# Altium schematic sheet Sheet2.SchDoc
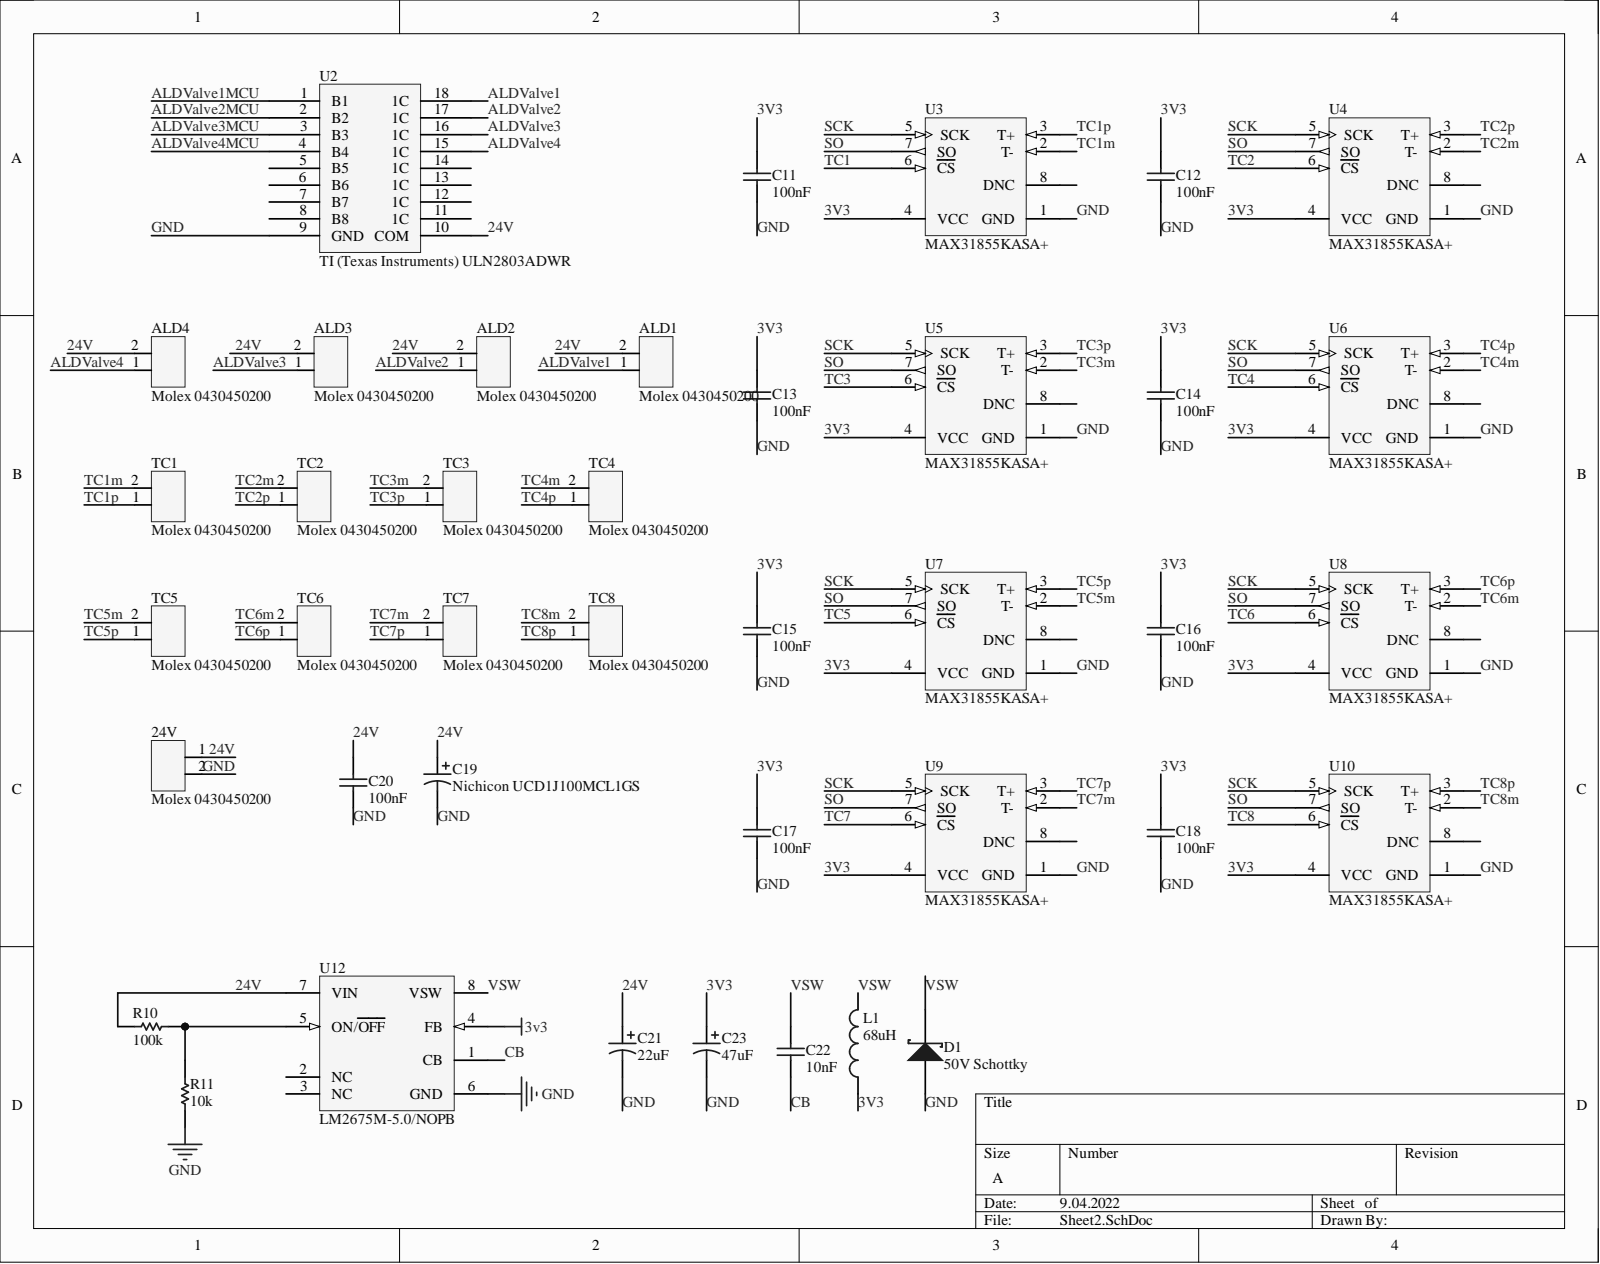
[diagram p0: Sheet2.SchDoc]

## Parts
24V  Molex 0430450200  Manufacturer Part Number: 0430450200  [PCB-lpc9z9t8uewl5xeystzy-1]
ALD1  Molex 0430450200  [PCB-lpc9z9t8uewl5xeystzy-1]
ALD2  Molex 0430450200  Manufacturer Part Number: 0430450200  [PCB-lpc9z9t8uewl5xeystzy-1]
ALD3  Molex 0430450200  Manufacturer Part Number: 0430450200  [PCB-lpc9z9t8uewl5xeystzy-1]
ALD4  Molex 0430450200  Manufacturer Part Number: 0430450200  [PCB-lpc9z9t8uewl5xeystzy-1]
C11  100nF  [CAPC1005X56X25NL10T15]
C12  100nF  [CAPC1005X56X25NL10T15]
C13  100nF  [CAPC1005X56X25NL10T15]
C14  100nF  [CAPC1005X56X25NL10T15]
C15  100nF  [CAPC1005X56X25NL10T15]
C16  100nF  [CAPC1005X56X25NL10T15]
C17  100nF  [CAPC1005X56X25NL10T15]
C18  100nF  [CAPC1005X56X25NL10T15]
C19  Nichicon UCD1J100MCL1GS  [PCB-1g0l0k2l0mdn54ua3y2n-1]
C20  100nF  [CAPC1005X56X25NL10T15]
C21  22uF  [PCB-1g0l0k2l0mdn54ua3y2n-1]
C22  10nF  [CAPC1005X56X25NL10T15]
C23  47uF  [PCB-1g0l0k2l0mdn54ua3y2n-1]
D1  50V Schottky  [SMB]
L1  68uH  [PCB-55q20qg1bv3zb075xii5-1]
R10  100k  [PCB-xna89c7rj2sv02b80bkg-1]
R11  10k  [PCB-xna89c7rj2sv02b80bkg-1]
TC1  Molex 0430450200  [PCB-lpc9z9t8uewl5xeystzy-1]
TC2  Molex 0430450200  Manufacturer Part Number: 0430450200  [PCB-lpc9z9t8uewl5xeystzy-1]
TC3  Molex 0430450200  Manufacturer Part Number: 0430450200  [PCB-lpc9z9t8uewl5xeystzy-1]
TC4  Molex 0430450200  Manufacturer Part Number: 0430450200  [PCB-lpc9z9t8uewl5xeystzy-1]
TC5  Molex 0430450200  [PCB-lpc9z9t8uewl5xeystzy-1]
TC6  Molex 0430450200  Manufacturer Part Number: 0430450200  [PCB-lpc9z9t8uewl5xeystzy-1]
TC7  Molex 0430450200  Manufacturer Part Number: 0430450200  [PCB-lpc9z9t8uewl5xeystzy-1]
TC8  Molex 0430450200  Manufacturer Part Number: 0430450200  [PCB-lpc9z9t8uewl5xeystzy-1]
U2  TI (Texas Instruments) ULN2803ADWR  [PCB-19353911-1]
U3  MAX31855KASA+  [MAXM-S8+4_N]
U4  MAX31855KASA+  [MAXM-S8+4_N]
U5  MAX31855KASA+  [MAXM-S8+4_N]
U6  MAX31855KASA+  [MAXM-S8+4_N]
U7  MAX31855KASA+  [MAXM-S8+4_N]
U8  MAX31855KASA+  [MAXM-S8+4_N]
U9  MAX31855KASA+  [MAXM-S8+4_N]
U10  MAX31855KASA+  [MAXM-S8+4_N]
U12  LM2675M-5.0/NOPB  [M08A_M]

## Nets
3V3: C11.2 C12.2 C13.2 C14.2 C15.2 C16.2 C17.2 C18.2 C23.1 L1.1 U3.4(VCC) U4.4(VCC) U5.4(VCC) U6.4(VCC) U7.4(VCC) U8.4(VCC) U9.4(VCC) U10.4(VCC) U12.4(FB)
24V: 24V.1 ALD1.2 ALD2.2 ALD3.2 ALD4.2 C19.1 C20.2 C21.1 R10.2 U2.10(COM) U12.7(VIN)
ALDValve1: ALD1.1 U2.18(1C)
ALDValve1MCU: U2.1(B1)
ALDValve2: ALD2.1 U2.17(1C)
ALDValve2MCU: U2.2(B2)
ALDValve3: ALD3.1 U2.16(1C)
ALDValve3MCU: U2.3(B3)
ALDValve4: ALD4.1 U2.15(1C)
ALDValve4MCU: U2.4(B4)
CB: C22.1 U12.1(CB)
GND: 24V.2 C11.1 C12.1 C13.1 C14.1 C15.1 C16.1 C17.1 C18.1 C19.2 C20.1 C21.2 C23.2 D1.2 R11.2 U2.9(GND) U3.1(GND) U4.1(GND) U5.1(GND) U6.1(GND) U7.1(GND) U8.1(GND) U9.1(GND) U10.1(GND) U12.6(GND)
SCK: U3.5(SCK) U4.5(SCK) U5.5(SCK) U6.5(SCK) U7.5(SCK) U8.5(SCK) U9.5(SCK) U10.5(SCK)
SO: U3.7(SO) U4.7(SO) U5.7(SO) U6.7(SO) U7.7(SO) U8.7(SO) U9.7(SO) U10.7(SO)
TC1: U3.6(CS)
TC1m: TC1.2 U3.2(T-)
TC1p: TC1.1 U3.3(T+)
TC2: U4.6(CS)
TC2m: TC2.2 U4.2(T-)
TC2p: TC2.1 U4.3(T+)
TC3: U5.6(CS)
TC3m: TC3.2 U5.2(T-)
TC3p: TC3.1 U5.3(T+)
TC4: U6.6(CS)
TC4m: TC4.2 U6.2(T-)
TC4p: TC4.1 U6.3(T+)
TC5: U7.6(CS)
TC5m: TC5.2 U7.2(T-)
TC5p: TC5.1 U7.3(T+)
TC6: U8.6(CS)
TC6m: TC6.2 U8.2(T-)
TC6p: TC6.1 U8.3(T+)
TC7: U9.6(CS)
TC7m: TC7.2 U9.2(T-)
TC7p: TC7.1 U9.3(T+)
TC8: U10.6(CS)
TC8m: TC8.2 U10.2(T-)
TC8p: TC8.1 U10.3(T+)
VSW: C22.2 D1.1 L1.2 U12.8(VSW)
NetR10_1: R10.1 R11.1 U12.5(ON/OFF)

Not connected: U2 5(B5) 6(B6) 7(B7) 8(B8) 11(1C) 12(1C) 13(1C) 14(1C); U3 8(DNC); U4 8(DNC); U5 8(DNC); U6 8(DNC); U7 8(DNC); U8 8(DNC); U9 8(DNC); U10 8(DNC); U12 2(NC) 3(NC)

## Library data of the parts
24V ALD1-ALD4 TC1-TC8 = CMP-b9e170746c1a6626-2: Conn Wire to Board HDR 2 POS Solder RA Thru-Hole Micro-Fit 3.0Ã¢âÂ¢ Tray; Component Kind: Standard; Contact Plating: Tin; Flammability Rating: UL 94 V-0; Library Name: CommunityVault; Lifecycle Status: Not Listed by Manufacturer; Octopart URL: http://octopart.com/0430450200-molex-10481821; OctopartID: b9e170746c1a6626; Orientation: Right Angle; RoHS: Compliant
  ALD1 TC1 TC5: Manufacturer Part Number: 0430450200
C11-C18 C20 C22 = CMP-10875562-2: Capacitor 0.1 uF 16 Volt Y5 Volt -20% To 80% Surface Mount 0402 Paper Tape and Reel; Capacitance: 1e-07 F; Capacitance Tolerance: +80/-20%; Dielectric Characteristic: Y5V; Dielectric Material: Ceramic Multilayer; Lead-Free Status: Lead Free; Voltage Rating (DC): 16.0 V; Insulation Resistance: 1e+11 ?; Case/Package: 0402; Component Kind: Standard; Library Name: CommunityVault; Mounting Style: Surface Mount; Number of Pins: 2; Octopart Url: https://octopart.com/0402yg104zat2a-avx+interconnect+%2F+elco-38554; OctopartID: 7a36b33cc9caa5f1; Packaging: Cut Tape (CT); REACH SVHC Compliance: No SVHC; RoHS: Compliant; Size-Height: 0.00056 m; Size-Length: 0.001 m; Size-Thickness: 0.0008636 m; Size-Width: 0.0005 m
C19 = CMP-5924905658d086e3-1: CD Series 10 uF 63 V 105°C Ø6.3 x 5.8mm SMT Aluminum Electrolytic Cap; Capacitance: 10u; Capacitance Tolerance: ±20%; Lead-Free Status: Lead Free; Voltage Rating (DC): 63V; Component Kind: Standard; Digikey: 493-6395-1-ND; Library Name: CommunityVault; Manufacturer Part Number: UCD1J100MCL1GS; Mounting Style: Surface Mount; Octopart URL: http://octopart.com/ucd1j100mcl1gs-nichicon-7873682; OctopartID: 5924905658d086e3; Packaging: Reel; RoHS: Compliant; Size-Diameter: 0.0063 m; Size-Height: 0.0058 m
C21 C23 = CMP-5924905658d086e3-1: CD Series 10 uF 63 V 105°C Ø6.3 x 5.8mm SMT Aluminum Electrolytic Cap; Manufacturer Part Number: UCD1J100MCL1GS; Capacitance: 10u; Capacitance Tolerance: ±20%; Lead-Free Status: Lead Free; Voltage Rating (DC): 63V; Component Kind: Standard; Digikey: 493-6395-1-ND; Library Name: CommunityVault; Mounting Style: Surface Mount; Octopart URL: http://octopart.com/ucd1j100mcl1gs-nichicon-7873682; OctopartID: 5924905658d086e3; Packaging: Reel; RoHS: Compliant; Size-Diameter: 0.0063 m; Size-Height: 0.0058 m
D1 = CMP-814764-4: DIODE SCHOTTKY 40V 1A SMA; Current Rating: 1.0 A; Lead-Free Status: Lead Free; Polarity: Standard; Voltage Rating (DC): 40.0 V; Case/Package: DO-214; Component Kind: Standard; Library Name: CommunityVault; Lifecycle Status: Not Listed by Manufacturer; Mounting Style: Surface Mount; Number of Pins: 2; Octopart Url: https://octopart.com/ss14-fairchild+semiconductor-55390225; OctopartID: ce2a046ddb5d11ed; REACH SVHC Compliance: No SVHC; RoHS: Compliant
L1 = CMP-57049c55be0788c3-2: RF Choke Wirewound 1mH 10% 252KHz 50Q-Factor Ferrite 290mA 2.9Ohm DCR RDL Bag; Manufacturer Part Number: RL622-102K-RC; Lead Length: 0.005 m; Lead-Free Status: Lead Free; Q-Factor: 50.0; Self Resonant Frequency: 1300000.0 Hz; Shielding: Yes; Inductance: 0.001 H; Component Kind: Standard; Library Name: FavoritesLibrary; Mounting Style: Through Hole; Number of Pins: 2; Octopart URL: http://octopart.com/rl622-102k-rc-bourns-39570533; OctopartID: 57049c55be0788c3; Orientation: Vertical; Packaging: Box; REACH SVHC Compliance: No SVHC; RoHS: Compliant; Size-Diameter: 0.0085 m; Size-Height: 0.011 m; Size-Width: 0.0085 m
R10 R11 = CMP-4716668-10: Res Thick Film 0603 10K Ohm 1% 0.1W(1/10W) ?100ppm/C SMD Automotive Paper T/R; Manufacturer Part Number: CRCW060310K0FKEA; Lead-Free Status: Lead Free; Temperature Coefficient: 100.0 ppm/°C; Voltage Rating (DC): 75.0 V; Case/Package: 0603; Component Kind: Standard; Library Name: CommunityVault; Mounting Style: Surface Mount; Octopart URL: http://octopart.com/crcw060310k0fkea-vishay-55388213; OctopartID: 4aec80ab47bf952b; Power Rating: 0.1 W; Resistance: 10k; Resistance Tolerance: ±1%; RoHS: Compliant
U2 = CMP-19353911-2: TEXAS INSTRUMENTS   ULN2803ADWR   DARLINGTON TRANSISTOR ARRAY; Current Rating: 0.5 A; Drivers Per Package: 8; Lead-Free Status: Lead Free; Voltage Rating (DC): 50.0 V; Case/Package: SOIC; Component Kind: Standard; Library Name: CommunityVault; Lifecycle Status: Active; Mounting Style: Surface Mount; Number of Pins: 18; Octopart Url: https://octopart.com/uln2803adwr-texas+instruments-351822; OctopartID: 9c1b5514e73343dc; REACH SVHC Compliance: No SVHC; RoHS: Compliant
U3-U10 = CMP-1468732-1: Cold Junction Compensated Thermocouple to Digital Converter, 14 Bits Temperature Resolution, -40 to 125 degC, 8-Pin SOIC (S8+4), Pb-Free; Accuracy: ±6°C(Max); Dynamic Catalog: Thermocouple Amplifier, Conditioner; Function: Thermocouple Cold Junction Compensator; Other Names: 90-31855+K00; Output Alarm: No; Output Type: 3-Wire/SPIT Serial; Product Training Modules: Lead (SnPb) Finish for COTSLong-Term Supply Program; Reference Design Library: MAX31855EVKIT#: 14-bit Thermocouple Interface SPI; Sensing Temperature: -200°C ~ 1350°C; Sensor Type: External; Topology: ADC; Voltage - Supply: 3 V ~ 3.6 V; Category: Integrated Circuits (ICs); Ciiva URL: https://ciiva.com/part/MAX31855KASA+-1468732; CiivaID: 1468732; Component Kind: Standard; Family: PMIC - Thermal Management; Library Name: CommunityVault; Mounting Type: Surface Mount; Operating Temperature: -40°C ~ 125°C; Output Fan: No; Package / Case: 8-SOIC (0.154", 3.90mm Width); Packaging: Tube; Standard Package: 100; Supplier Device Package: 8-SOIC N
U12 = CMP-0069-00699-3: SIMPLE SWITCHER® Power Converter High Efficiency 1A Step-Down Voltage Regulator, 8-pin Narrow SOIC, Pb-Free; Current Rating: 1.0 A; Frequency: 260000.0 Hz; Lead-Free Status: Lead Free; Number of Outputs: 1; Output Voltage: 5.0 V; Quiescent Current: 0.0025 A; Topology: Buck; Case/Package: SOIC; Component Kind: Standard; Library Name: CommunityVault; Lifecycle Status: Active; Mounting Style: Surface Mount; Number of Pins: 8; Number of Regulated Outputs: 1; Octopart Url: https://octopart.com/lm2675m-5.0%2Fnopb-texas+instruments-24813115; OctopartID: db8613f660db0441; Output Current: 1.0 A; Packaging: Tube; REACH SVHC Compliance: No SVHC; RoHS: Compliant; Switching Frequency: 260000.0 Hz
